# Altium schematic sheet Sheet1.SchDoc(Sheet1)
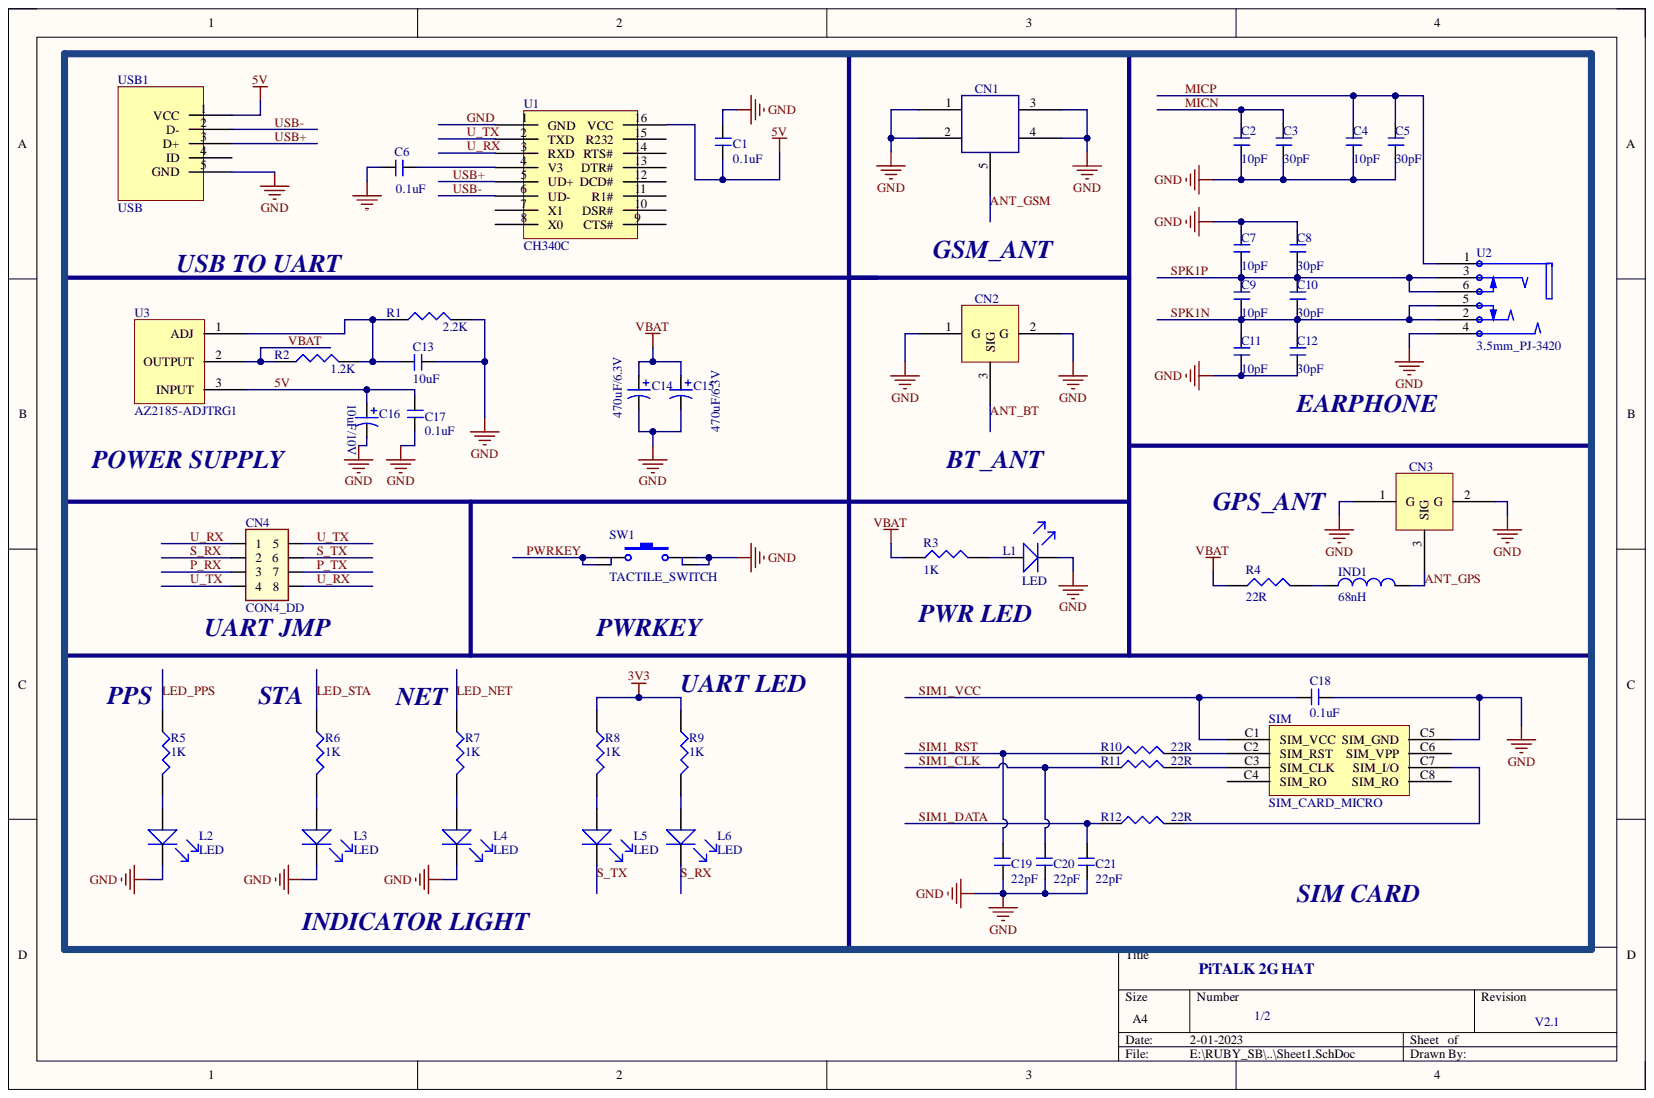
[diagram p0: Sheet1.SchDoc]

## Parts
C1  0.1uF  [CAP_0603_NONPOLAR]
C2  10pF  [CAP_0603_NONPOLAR]
C3  30pF  [CAP_0603_NONPOLAR]
C4  10pF  [CAP_0603_NONPOLAR]
C5  30pF  [CAP_0603_NONPOLAR]
C6  0.1uF  [CAP_0603_NONPOLAR]
C7  10pF  [CAP_0603_NONPOLAR]
C8  30pF  [CAP_0603_NONPOLAR]
C9  10pF  [CAP_0603_NONPOLAR]
C10  30pF  [CAP_0603_NONPOLAR]
C11  10pF  [CAP_0603_NONPOLAR]
C12  30pF  [CAP_0603_NONPOLAR]
C13  10uF  [CAP_0603_NONPOLAR]
C14  470uF/6.3V  [CASE-D_7343]
C15  470uF/6.3V  [CASE-D_7343]
C16  10uF/10V  [CAP_TANTALUM CASE-A_3216]
C17  0.1uF  [CAP_0603_NONPOLAR]
C18  0.1uF  [CAP_0603_NONPOLAR]
C19  22pF  [CAP_0603_NONPOLAR]
C20  22pF  [CAP_0603_NONPOLAR]
C21  22pF  [CAP_0603_NONPOLAR]
CN1  SMA  CONNECTOR  [SMA_CONNECTOR]  (value not drawn)
CN2  UFL CONNECTOR  [UFL_CONNECTOR]  (value not drawn)
CN3  UFL CONNECTOR  [UFL_CONNECTOR]  (value not drawn)
CN4  CON4_DD  [CON4_DD]
IND1  68nH  [0603]
L1  LED  [LED_0603_neg]
L2  LED  [LED_0603_neg]
L3  LED  [LED_0603_neg]
L4  LED  [LED_0603_neg]
L5  LED  [LED_0603_neg]
L6  LED  [LED_0603_neg]
R1  2.2K  [0603]
R2  1.2K  [0603]
R3  1K  [0603]
R4  22R  [0603]
R5  1K  [0603]
R6  1K  [0603]
R7  1K  [0603]
R8  1K  [0603]
R9  1K  [0603]
R10  22R  [0603]
R11  22R  [0603]
R12  22R  [0603]
SIM  SIM_CARD_MICRO  [SIM CARD MICRO  8P]
SW1  TACTILE_SWITCH  [SKRPACE010]
U1  CH340C  [SOIC_16]
U2  3.5mm_PJ-3420  [PJ-3420 X-SMT 3.5 PHONE JACK]
U3  AZ2185-ADJTRG1  [TO-252-3]
USB1  USB  [USB_MICRO]

## Nets
3V3: R8.1 R9.1
5V: C1.1 C16.A C17.2 U1.16(VCC) U3.3 USB1.1(VCC)
ANT_BT: CN2.3
ANT_GPS: CN3.3 IND1.2
ANT_GSM: CN1.5
GND: C1.2 C2.1 C3.1 C4.1 C5.1 C6.1 C7.2 C8.2 C11.1 C12.1 C13.2 C14.K C15.K C16.K C17.1 C18.1 C19.2 C20.2 C21.2 CN1.1 CN1.2 CN1.3 CN1.4 CN2.1 CN2.2 CN3.1 CN3.2 L1.K L2.K L3.K L4.K R1.2 SIM.C5(SIM_GND) SW1.3 SW1.4 U1.1(GND) U2.4 USB1.5(GND)
LED_NET: R7.1
LED_PPS: R5.1
LED_STA: R6.1
MICN: C2.2 C3.2
MICP: C4.2 C5.2 U2.1
P_RX: CN4.3
P_TX: CN4.7
PWRKEY: SW1.1 SW1.2
S_RX: CN4.2 L6.K
S_TX: CN4.6 L5.K
SIM1_CLK: C20.1 R11.1
SIM1_DATA: C21.1 R12.1
SIM1_RST: C19.1 R10.1
SIM1_VCC: C18.2 SIM.C1(SIM_VCC)
SPK1N: C9.1 C10.1 C11.2 C12.2 U2.2 U2.5
SPK1P: C7.1 C8.1 C9.2 C10.2 U2.3 U2.6
U_RX: CN4.1 CN4.8 U1.3(RXD)
U_TX: CN4.4 CN4.5 U1.2(TXD)
USB+: U1.5(UD+) USB1.3(D+)
USB-: U1.6(UD-) USB1.2(D-)
VBAT: C14.A C15.A R2.1 R3.1 R4.1 U3.2
NetC6_2: C6.2 U1.4(V3)
NetC13_1: C13.1 R1.1 R2.2 U3.1
NetIND1_1: IND1.1 R4.2
NetL1_A: L1.A R3.2
NetL2_A: L2.A R5.2
NetL3_A: L3.A R6.2
NetL4_A: L4.A R7.2
NetL5_A: L5.A R8.2
NetL6_A: L6.A R9.2
NetR10_2: R10.2 SIM.C2(SIM_RST)
NetR11_2: R11.2 SIM.C3(SIM_CLK)
NetR12_2: R12.2 SIM.C7(SIM_I/O)

Not connected: SIM C4(SIM_RO) C6(SIM_VPP) C8(SIM_RO); U1 7(X1) 8(X0) 9(CTS#) 10(DSR#) 11(R1#) 12(DCD#) 13(DTR#) 14(RTS#) 15(R232); USB1 4(ID)

## Library data of the parts
C1-C13 C17-C21 = CAP_NONPOLAR: Component Kind: Standard; Library Name: dxp_pasl_lib.IntLib
C14-C16 = CAP_ELEC: Component Kind: Standard; Library Name: dxp_pasl_lib.IntLib
CN1 = SMA CONNECTOR: Component Kind: Standard; Library Name: dxp_pasl_lib.IntLib
CN2 CN3 = UFL_CONNECTOR: Component Kind: Standard; Library Name: dxp_pasl_lib.IntLib
IND1 = INDUCTOR: Component Kind: Standard; Library Name: dxp_pasl_lib.IntLib
R1-R12 = RES: Component Kind: Standard; Library Name: dxp_pasl_lib.IntLib
U2 = Headphone Holder SMD: Component Kind: Standard; Library Name: dxp_pasl_lib.IntLib
U3 = AZ2185-ADJTRG1: 3A LOW DROPOUT LINEAR REGULATOR TO-252-3 PACKAGE; Component Kind: Standard; Library Name: dxp_pasl_lib.IntLib
USB1 = USB_MICRO: Component Kind: Standard; Library Name: dxp_pasl_lib.IntLib
CN4 = CON4_DD: Component Kind: Standard; Library Name: dxp_pasl_lib.IntLib
L1-L6 = LED: Component Kind: Standard; Library Name: dxp_pasl_lib.IntLib
SIM = SIM_CARD_MICRO: Component Kind: Standard; Library Name: dxp_pasl_lib.SCHLIB
SW1 = TACTILE_SWITCH: Component Kind: Standard; Library Name: dxp_pasl_lib.IntLib
U1 = CH340C: Component Kind: Standard; Library Name: dxp_pasl_lib.IntLib
